# Altium schematic sheet A3-USB.SchDoc
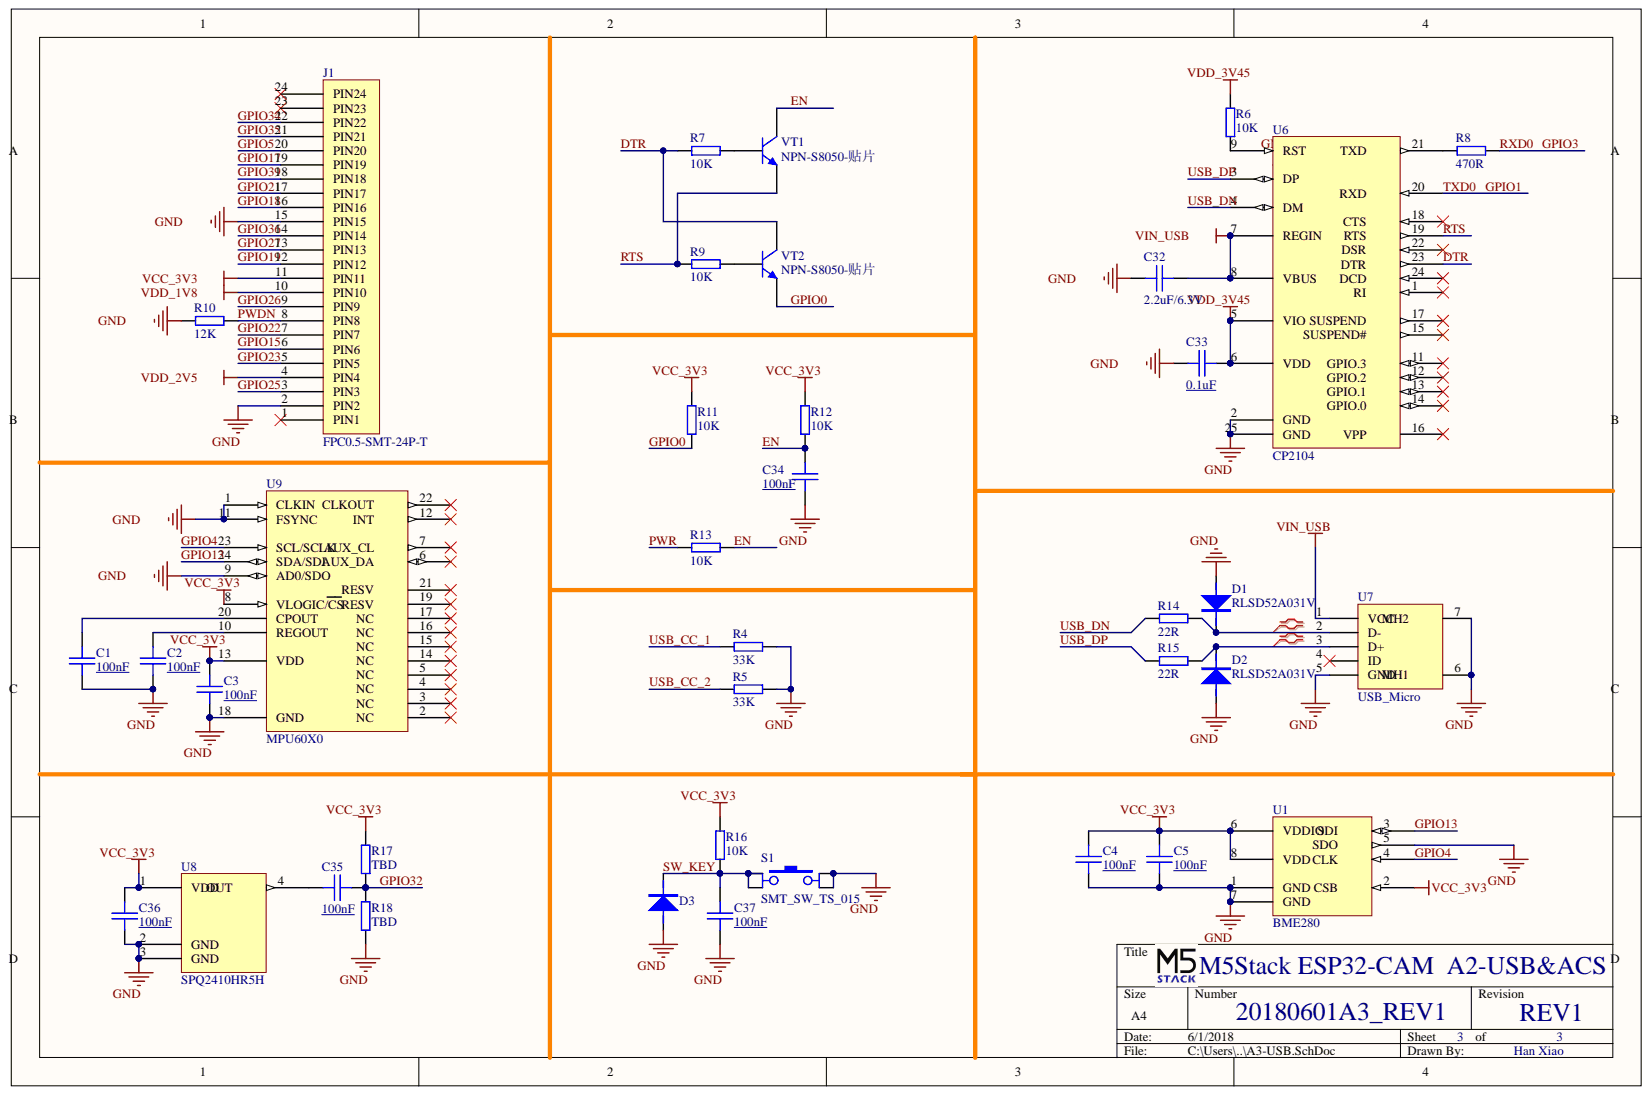
[diagram p0: A3-USB.SchDoc]

## Parts
C1  100nF  [SMT_C_0402]
C2  100nF  [SMT_C_0402]
C3  100nF  [SMT_C_0402]
C4  100nF  [SMT_C_0402]
C5  100nF  [SMT_C_0402]
C32  2.2uF/6.3V  [SMT_C_0402]
C33  0.1uF  [SMT_C_0402]
C34  100nF  [SMT_C_0402]
C35  100nF  [SMT_C_0402]
C36  100nF  [SMT_C_0402]
C37  100nF  [SMT_C_0402]
D1  RLSD52A031V  [SMT_DIODE_SOD_323]
D2  RLSD52A031V  [SMT_DIODE_SOD_323]
D3  RLSD52A031V  [SMT_DIODE_SOD_323]
J1  FPC0.5-SMT-24P-T  [FPC0.5-SMT-24P-T-DRAWER]
R4  33K  [SMT_R_0402]
R5  33K  [SMT_R_0402]
R6  10K  [SMT_R_0402]
R7  10K  [SMT_R_0402]
R8  470R  [SMT_R_0402]
R9  10K  [SMT_R_0402]
R10  12K  [SMT_R_0402]
R11  10K  [SMT_R_0402]
R12  10K  [SMT_R_0402]
R13  10K  [SMT_R_0402]
R14  22R  [SMT_R_0402]
R15  22R  [SMT_R_0402]
R16  10K  [SMT_R_0402]
R17  TBD  [SMT_R_0402]
R18  TBD  [SMT_R_0402]
S1  SMT_SW_TS_015  [SMT_SW_TS_015]
U1  BME280  [BME280]
U6  CP2104  [PQFN50P400X400X80_HS-25N]
U7  USB_Micro  [M5-USB-TC-2]
U8  SPQ2410HR5H  [SPQ2410HR5H]
U9  MPU60X0  [MPU60X0]
VT1  NPN-S8050-贴片  [NPN-S8050-贴片]
VT2  NPN-S8050-贴片  [NPN-S8050-贴片]

## Nets
GND: C1.2 C2.2 C3.2 C4.2 C5.2 C32.1 C33.1 C34.1 C36.1 C37.1 D1.1 D2.1 D3.1 J1.2(PIN2) J1.15(PIN15) R4.1 R5.1 R10.2 R18.1 S1.3 S1.4 U1.1 U1.5 U1.7(GND) U6.2(GND) U6.25(GND) U7.5(GND) U7.6 U7.7 U8.2(GND) U8.3(GND) U9.1(CLKIN) U9.9(AD0/SDO) U9.11(FSYNC) U9.18(GND)
VCC_3V3: C3.1 C4.1 C5.1 C36.2 J1.11(PIN11) R11.2 R12.2 R16.2 R17.2 U1.2 U1.6(VDDIO) U1.8 U8.1(VDD) U9.8(VLOGIC/CS) U9.13(VDD)
VDD_1V8: J1.10(PIN10)
VDD_2V5: J1.4(PIN4)
VDD_3V45: C33.2 R6.1 U6.5 U6.6(VDD)
VIN_USB: C32.2 U6.7(REGIN) U6.8(VBUS) U7.1(VCC)
DTR: R7.2 U6.23 VT2.3
EN: C34.2 R12.1 R13.1 VT1.3
GPIO0: R11.1 VT2.2
GPIO1: U6.20
GPIO3: R8.1
GPIO4: U1.4 U9.23(SCL/SCLK)
GPIO5: J1.20(PIN20)
GPIO13: U1.3 U9.24(SDA/SDI)
GPIO15: J1.6(PIN6)
GPIO17: J1.19(PIN19)
GPIO18: J1.16(PIN16)
GPIO19: J1.12(PIN12)
GPIO21: J1.17(PIN17)
GPIO22: J1.7(PIN7)
GPIO23: J1.5(PIN5)
GPIO25: J1.3(PIN3)
GPIO26: J1.9(PIN9)
GPIO27: J1.13(PIN13)
GPIO32: C35.1 R17.1 R18.2
GPIO34: J1.22(PIN22)
GPIO35: J1.21(PIN21)
GPIO36: J1.14(PIN14)
GPIO39: J1.18(PIN18)
PWDN: J1.8(PIN8) R10.1
PWR: R13.2
RTS: R9.2 U6.19 VT1.2
SW_KEY: C37.2 D3.2 R16.1 S1.1 S1.2
USB_CC_1: R4.2
USB_CC_2: R5.2
USB_DN: R14.2 U6.4(DM)
USB_DP: R15.2 U6.3(DP)
NetC1_1: C1.1 U9.20(CPOUT)
NetC2_1: C2.1 U9.10(REGOUT)
NetC35_2: C35.2 U8.4
NetD1_2: D1.2 R14.1 U7.2(D-)
NetD2_2: D2.2 R15.1 U7.3(D+)
NetR6_2: R6.2 U6.9(RST)
NetR7_1: R7.1 VT1.1
NetR8_2: R8.2 U6.21
NetR9_1: R9.1 VT2.1
DSR:

Not connected: J1 1(PIN1) 23(PIN23) 24(PIN24); U6 1 11 12 13 14 15 16 17 18 22 24; U7 4(ID); U9 2 3 4 5 6 7 12 14 15 16 17 19 21 22

## Library data of the parts
C1-C5 C32-C37 = SMT_C_0402: Surface mount capacitor 0402; Component Kind: Standard; Library Name: Vowstar_Basic_Device.IntLib
D1-D3 = SMT_DIODE_SOD_323: Surface mount diode SOD-323(0805) Package; Component Kind: Standard; Library Name: Vowstar_Basic_Device.IntLib
R4-R13 R16-R18 = SMT_R_0402: Surface mount resistor 0402; Component Kind: Standard; Library Name: Vowstar_Basic_Device.IntLib
R14 R15 = SMT_R_0603: Surface mount resistor 0603; Component Kind: Standard; Library Name: Vowstar_Basic_Device.IntLib
U6 = CP2104: 单芯片USB转UART桥接器; Component Kind: Standard; Library Name: USB-REL-CHUP.SchLib
U7 = USB_Micro: USB micro female; Component Kind: Standard; Library Name: Vowstar_Connector.IntLib
U9 = MPU60X0: MPU60X0 Six-Axis (Gyro + Accelerometer) MEMS; Component Kind: Standard; Library Name: Vowstar_IC_General.IntLib
J1 = FPC0.5-SMT-24P-T: Component Kind: Standard; Description: FPC0.5-SMT-24P-T; Library Name: Vowstar_Connector.IntLib
S1 = SMT_SW_TS_015: Component Kind: Standard; Description: SMT_SW_TS_015; Library Name: Vowstar_Switch.IntLib
U1 = BME280: Component Kind: Standard; Library Name: GENERAL-IC.SchLib
U8 = SPQ2410HR5H: Component Kind: Standard; Library Name: GENERAL-IC.SchLib
VT1 VT2 = NPN-S8050-贴片: Component Kind: Standard; Description: NPN-S8050-贴片; Library Name: Vowstar_Basic_Device.IntLib
